# Altium schematic sheet Schematic_Lightfollower_v1.1.SchDoc
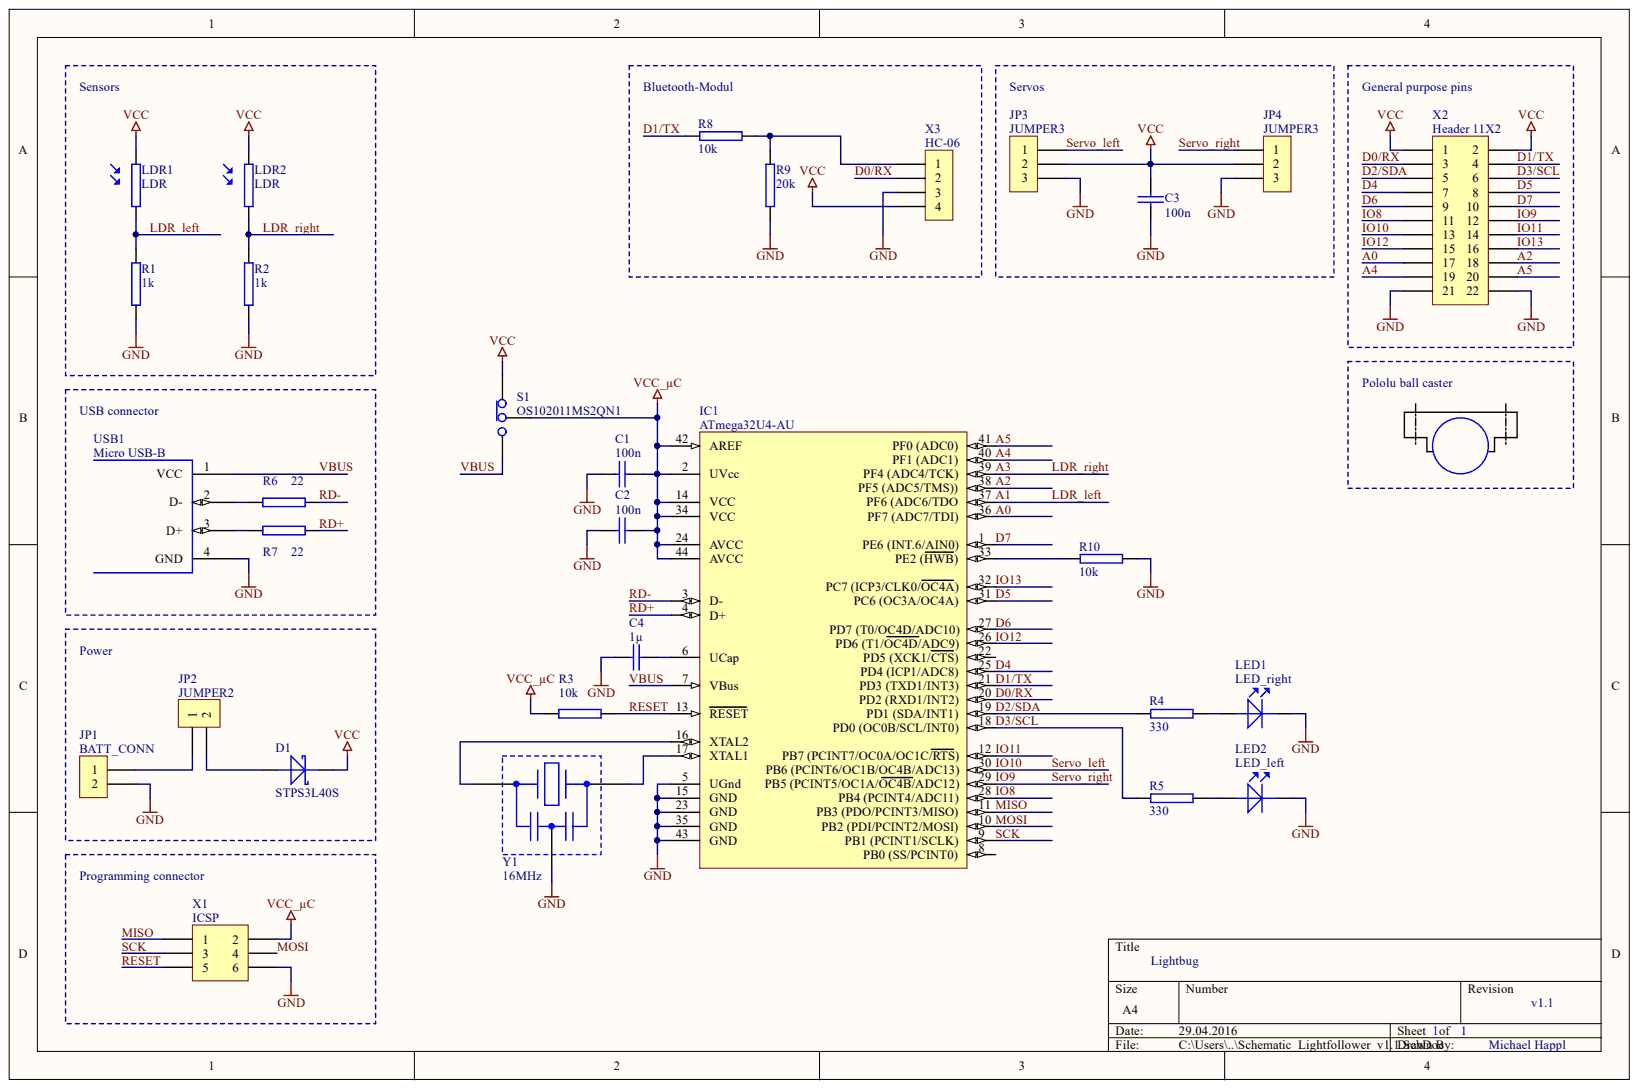
[diagram p0: Schematic_Lightfollower_v1.1.SchDoc]

## Parts
Ball_caster  Ball caster  [Pololu Ball Caster]  (value not drawn)
C1  100n  [0805_1]
C2  100n  [0805_1]
C3  100n  [RAD-0.1]
C4  1µ  [0805_1]
D1  STPS3L40S  [DO-214AB]
IC1  ATmega32U4-AU  [44ML_L]
JP1  BATT_CONN  [Jumper2]
JP2  JUMPER2  [Jumper2]
JP3  JUMPER3  [Jumper3]
JP4  JUMPER3  [Jumper3]
LDR1  LDR  [R0.1_stehend]
LDR2  LDR  [R0.1_stehend]
LED1  LED_right  [LED-3MM]
LED2  LED_left  [LED-3MM]
R1  1k  [R0.4]
R2  1k  [R0.4]
R3  10k  [0805_1]
R4  330  [R0.4]
R5  330  [R0.4]
R6  22  [0805_1]
R7  22  [0805_1]
R8  10k  [R0.4]
R9  20k  [R0.4]
R10  10k  [0805_1]
S1  OS102011MS2QN1  [SPDT Switch]
USB1  Micro USB-B  [USB-B Micro]
X1  ICSP  [HDR2X3]
X2  Header 11X2  [HDR2X11]
X3  HC-06  [Jumper4]
Y1  16MHz  [Resonator]

## Nets
GND: C1.1 C2.1 C3.1 C4.1 IC1.5(UGnd) IC1.15(GND) IC1.23(GND) IC1.35(GND) IC1.43(GND) JP1.2 JP3.3 JP4.3 LED1.K LED2.K R1.1 R2.1 R9.1 R10.1 USB1.4(GND) X1.6 X2.21 X2.22 X3.3 Y1.2
VCC: C3.2 D1.2 JP3.2 JP4.2 LDR1.2 LDR2.2 S1.3 X2.1 X2.2 X3.4
VCC_µC: C1.2 C2.2 IC1.2(UVcc) IC1.14(VCC) IC1.24(AVCC) IC1.34(VCC) IC1.42(AREF) IC1.44(AVCC) R3.1 S1.2 X1.2
A0: IC1.36(PF7_(ADC7/TDI)) X2.17
A1: IC1.37(PF6_(ADC6/TDO) LDR1.1 R1.2
A2: IC1.38(PF5_(ADC5/TMS))) X2.18
A3: IC1.39(PF4_(ADC4/TCK)) LDR2.1 R2.2
A4: IC1.40(PF1_(ADC1)) X2.19
A5: IC1.41(PF0_(ADC0)) X2.20
D0/RX: IC1.20(PD2_(RXD1/INT2)) X2.3 X3.2
D1/TX: IC1.21(PD3_(TXD1/INT3)) R8.2 X2.4
D2/SDA: IC1.19(PD1_(SDA/INT1)) R4.1 X2.5
D3/SCL: IC1.18(PD0_(OC0B/SCL/INT0)) R5.1 X2.6
D4: IC1.25(PD4_(ICP1/ADC8)) X2.7
D5: IC1.31(PC6_(OC3A/OC4A)) X2.8
D6: IC1.27(PD7_(T0/OC4D/ADC10)) X2.9
D7: IC1.1(PE6_(INT.6/AIN0)) X2.10
IO8: IC1.28(PB4_(PCINT4/ADC11)) X2.11
IO9: IC1.29 JP4.1 X2.12
IO10: IC1.30(PB6_(PCINT6/OC1B/OC4B/ADC13)) JP3.1 X2.13
IO11: IC1.12 X2.14
IO12: IC1.26(PD6_(T1/OC4D/ADC9)) X2.15
IO13: IC1.32(PC7_(ICP3/CLK0/OC4A)) X2.16
MISO: IC1.11 X1.1
MOSI: IC1.10 X1.4
RD+: IC1.4(D+) R7.2
RD-: IC1.3(D-) R6.2
RESET: IC1.13(RESET) R3.2 X1.5
SCK: IC1.9(PB1_(PCINT1/SCLK)) X1.3
VBUS: IC1.7(VBus) S1.1 USB1.1(VCC)
NetC4_2: C4.2 IC1.6(UCap)
NetD1_1: D1.1 JP2.2
NetIC1_16: IC1.16(XTAL2) Y1.1
NetIC1_17: IC1.17(XTAL1) Y1.3
NetIC1_33: IC1.33(PE2_(HWB)) R10.2
NetJP1_1: JP1.1 JP2.1
NetLED1_A: LED1.A R4.2
NetLED2_A: LED2.A R5.2
NetR6_1: R6.1 USB1.2(D-)
NetR7_1: R7.1 USB1.3(D+)
NetR8_1: R8.1 R9.2 X3.1

Not connected: IC1 8(PB0_(SS/PCINT0)) 22(PD5_(XCK1/CTS))

## Library data of the parts
Ball_caster = Ball roller: Ball roller for small robots; Component Kind: Standard; Library Name: happ_uC.LIB
C1-C4 = KO: Kondensator; Component Kind: Standard; Library Name: DANG_Diskret.Lib
D1 = DIODE SCHOTTKY: Schottky Diode; Component Kind: Standard; Library Name: DANG_Diskret.Lib
IC1 = ATmega32U4-AU: 8-bit AVR Microcontroller, 2.7-5.5V, 32KB Flash, 2.5KB EEPROM, 1KB SRAM, USB Controller, 44-pin TQFP, Industrial Grade (-40°C to 85°C); Component Kind: Standard; ComponentLink1Description: Manufacturer Link; ComponentLink1URL: http://www.atmel.com/products/avr/default.asp?; ComponentLink2Description: ATmega16U4/32U4 Datasheet; ComponentLink2URL: http://www.atmel.com/dyn/resources/prod_documents/doc7766.pdf; ComponentLink3Description: ATmega16U4 ATmega32U4 Summary; ComponentLink3URL: http://www.atmel.com/dyn/resources/prod_documents/7766S.pdf; ComponentLink4Description: Atmel.com Home; ComponentLink4URL: http://www.atmel.com; DatasheetVersion: revD, Jan-2009; Library Name: Atmel Microcontroller 8-Bit megaAVR.IntLib; PackageDescription: 44-Pin Plastic Thin Quad Flat Pack, 10 x 10 x 1.0 mm Body, 0.8 mm Pitch (TQFP); PackageReference: 44ML; PackageVersion: rev.G, Jul-2007; Published: 1-Apr-2010; Supplier 1: Digi-Key; Supplier 2: Farnell; Supplier 3: Mouser; Supplier Part Number 1: ATMEGA32U4-AU-ND; Supplier Part Number 2: 1748525; Supplier Part Number 3: 556-ATMEGA32U4-AU; Publisher: Altium Limited
JP1 JP2 = JUMPER2: Jumper; Component Kind: Standard; Library Name: DANG_Stecker.Lib
JP3 JP4 = JUMPER3: Jumper; Component Kind: Standard; Library Name: DANG_Stecker.Lib
LDR1 LDR2 = LDR: Fotowiderstand; Component Kind: Standard; Library Name: DANG_Opto.Lib
LED1 LED2 = LED: Component Kind: Standard; Description: LED; Library Name: DANG_Opto.Lib
R1-R10 = R: Widerstand; Component Kind: Standard; Library Name: DANG_Diskret.Lib
S1 = Slide Switch: Component Kind: Standard; Description: Slide switch; Library Name: happ_uC.LIB
USB1 = USB-B: USB B-Type Connector; Component Kind: Standard; Library Name: happ_uC.LIB
X1 = Header 3X2: Header, 3-Pin, Dual row; Component Kind: Standard; LatestRevisionDate: 17-Jul-2002; LatestRevisionNote: Re-released for DXP Platform.; Library Name: Miscellaneous Connectors.IntLib; Signal Integrity: Connector; Publisher: Altium Limited
X2 = Header 11X2: Header, 11-Pin, Dual row; Component Kind: Standard; LatestRevisionDate: 17-Jul-2002; LatestRevisionNote: Re-released for DXP Platform.; Library Name: Miscellaneous Connectors.IntLib; Signal Integrity: Connector; Publisher: Altium Limited
X3 = JUMPER4: Jumper; Component Kind: Standard; Library Name: DANG_Stecker.Lib
Y1 = Resonator: Ceramic Crystal Resonator; Component Kind: Standard; Library Name: happ_uC.LIB
